# Altium schematic sheet USB_PMU.SchDoc(USB_PMU)
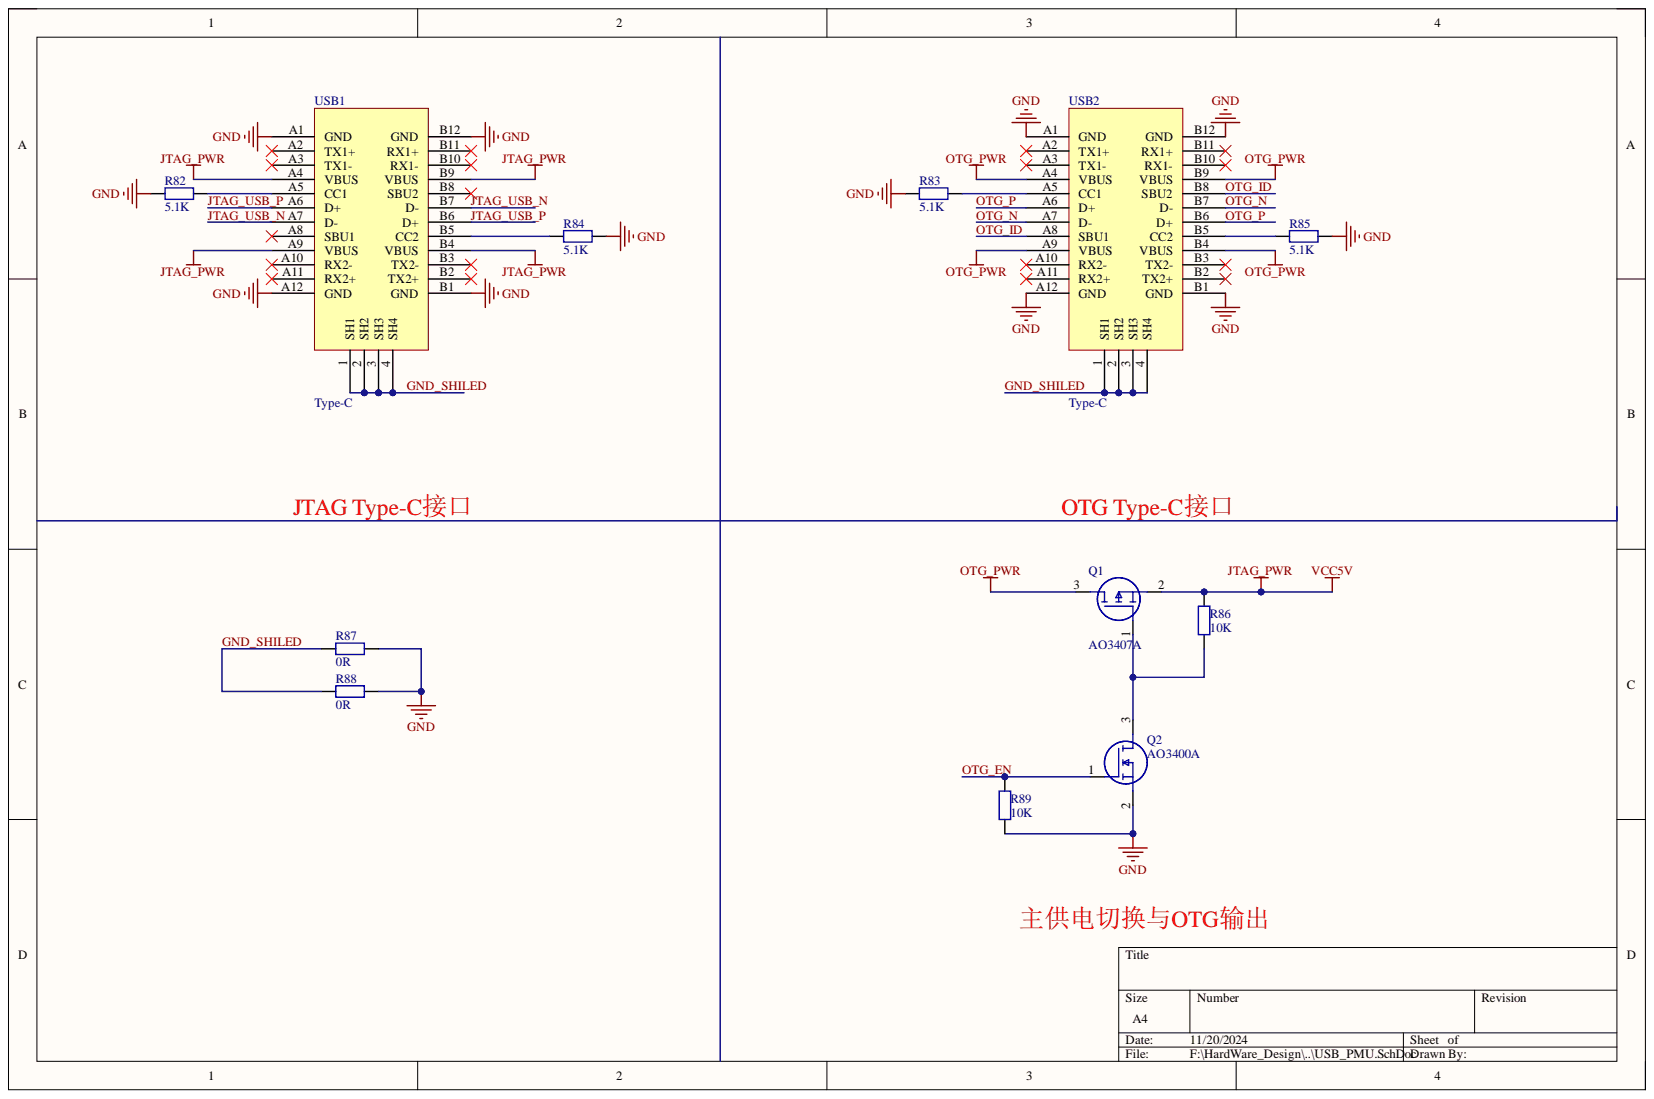
[diagram p0: USB_PMU.SchDoc]

## Parts
Q1  AO3407A  [SOT95P280X125-3N]
Q2  AO3400A  [SOT95P280X125-3N]
R82  5.1K  [R 0402_L]
R83  5.1K  [R 0402_L]
R84  5.1K  [R 0402_L]
R85  5.1K  [R 0402_L]
R86  10K  [R 0402_L]
R87  0R  [R 1206_L]
R88  0R  [R 1206_L]
R89  10K  [R 0402_L]
USB1  Type-C  [TYPE-C-16P]
USB2  Type-C  [TYPE-C-16P]

## Nets
GND: Q2.2 R82.1 R83.1 R84.1 R85.2 R87.2 R88.2 R89.1 USB1.A1(GND) USB1.A12(GND) USB1.B1(GND) USB1.B12(GND) USB2.A1(GND) USB2.A12(GND) USB2.B1(GND) USB2.B12(GND)
JTAG_PWR: Q1.2 R86.2 USB1.A4(VBUS) USB1.A9(VBUS) USB1.B4(VBUS) USB1.B9(VBUS)
OTG_PWR: Q1.3 USB2.A4(VBUS) USB2.A9(VBUS) USB2.B4(VBUS) USB2.B9(VBUS)
GND_SHILED: R87.1 R88.1 USB1.1(SH1) USB1.2(SH2) USB1.3(SH3) USB1.4(SH4) USB2.1(SH1) USB2.2(SH2) USB2.3(SH3) USB2.4(SH4)
JTAG_USB_N: USB1.A7(D-) USB1.B7(D-)
JTAG_USB_P: USB1.A6(D+) USB1.B6(D+)
OTG_EN: Q2.1 R89.2
OTG_ID: USB2.A8(SBU1) USB2.B8(SBU2)
OTG_N: USB2.A7(D-) USB2.B7(D-)
OTG_P: USB2.A6(D+) USB2.B6(D+)
NetQ1_1: Q1.1 Q2.3 R86.1
NetR82_2: R82.2 USB1.A5(CC1)
NetR83_2: R83.2 USB2.A5(CC1)
NetR84_2: R84.2 USB1.B5(CC2)
NetR85_1: R85.1 USB2.B5(CC2)

Not connected: USB1 A2(TX1+) A3(TX1-) A8(SBU1) A10(RX2-) A11(RX2+) B2(TX2+) B3(TX2-) B8(SBU2) B10(RX1-) B11(RX1+); USB2 A2(TX1+) A3(TX1-) A10(RX2-) A11(RX2+) B2(TX2+) B3(TX2-) B10(RX1-) B11(RX1+)

## Library data of the parts
Q1 = AO3407A: MOSFET (P-Channel); Manufacturer_Name: Alpha & Omega Semiconductors; Arrow Part Number: AO3407A; Arrow Price/Stock: https://www.arrow.com/en/products/ao3407a/alpha-and-omega-semiconductor?region=nac; Component Kind: Standard; Datasheet Link: http://www.aosmd.com/pdfs/datasheet/ao3407a.pdf; Height: 1.25mm; Library Name: SamacSys.SchLib; Manufacturer_Part_Number: AO3407A
Q2 = AO3400A: MOSFET (N-Channel); Manufacturer_Name: Alpha & Omega Semiconductors; Arrow Part Number: AO3400A; Arrow Price/Stock: https://www.arrow.com/en/products/ao3400a/alpha-and-omega-semiconductor?region=nac; Component Kind: Standard; Datasheet Link: http://aosmd.com/res/data_sheets/AO3400A.pdf; Height: 1.25mm; Library Name: SamacSys.SchLib; Manufacturer_Part_Number: AO3400A
R82 R84 R86-R89 = Res: 通用; Component Kind: Standard; Library Name: A_Schlib.SchLib
  R82 R84: value: 5.1K
  R86 R89: value: 10K
  R87 R88: value: 0R
R83 R85 = Res: Component Kind: Standard; value: 5.1K; Library Name: 零散元件库.SCHLIB
USB1 = Type-C-16P: 带通信; Component Kind: Standard; Library Name: A_Schlib.SchLib
USB2 = Type-C: Component Kind: Standard; Library Name: 零散元件库.SCHLIB
